# Altium schematic sheet 40-pin LCD Schematic.SchDoc("40-pin LCD Schematic")
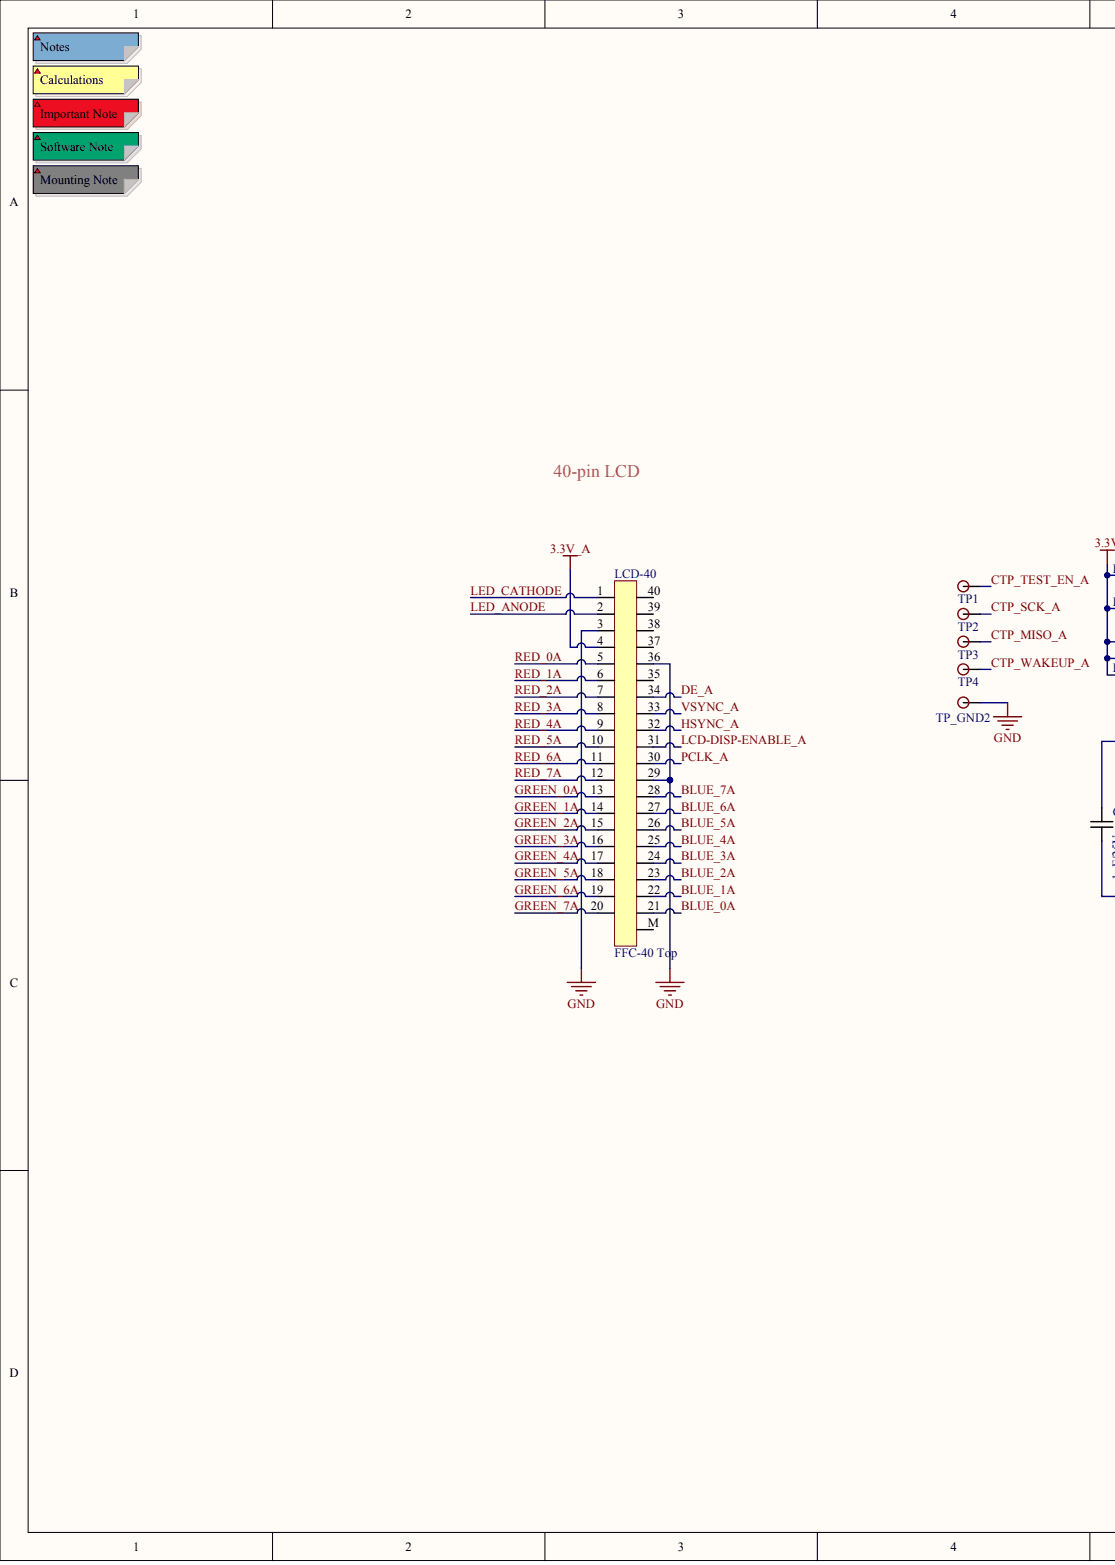
[diagram p0: 40-pin LCD Schematic.SchDoc, left]
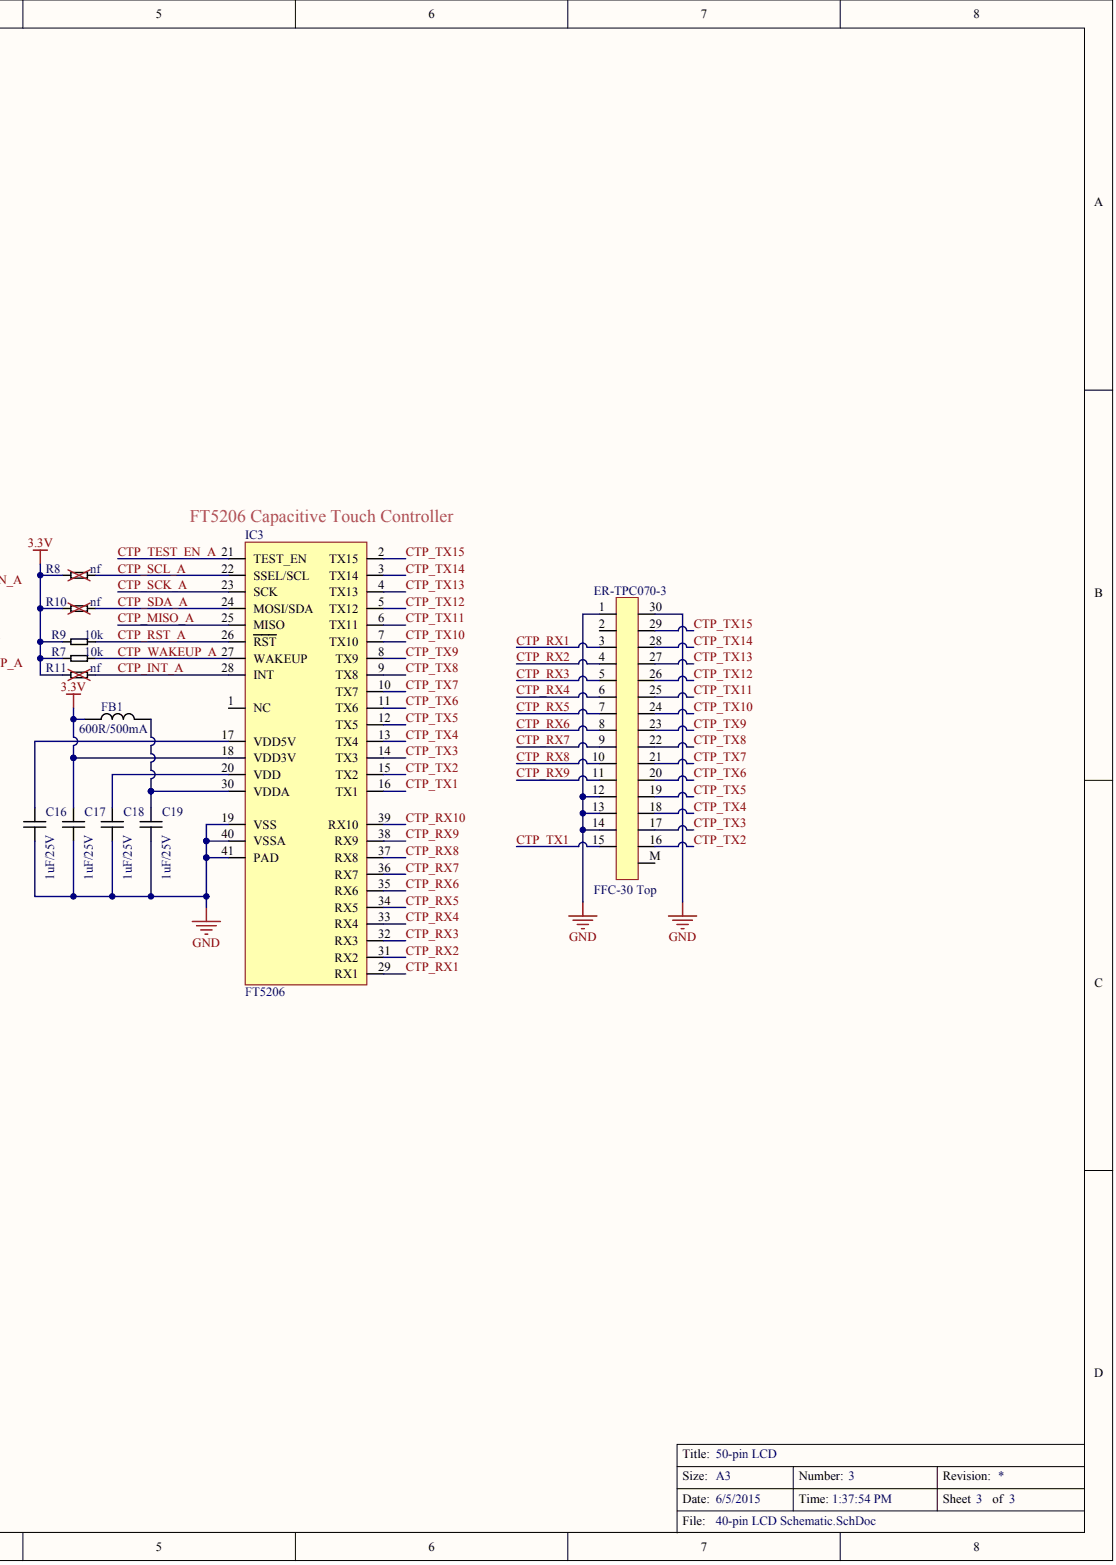
[diagram p1: 40-pin LCD Schematic.SchDoc, right]

## Parts
C16  value 1uF/25V  [0603]  (hidden comment: Cap_0603_1uF/25V)
C17  value 1uF/25V  [0603]  (hidden comment: Cap_0603_1uF/25V)
C18  value 1uF/25V  [0603]  (hidden comment: Cap_0603_1uF/25V)
C19  value 1uF/25V  [0603]  (hidden comment: Cap_0603_1uF/25V)
ER-TPC070-3  value FFC-30 Top  (hidden comment: FFC 30 pin 0.5mm Top)
FB1  value 600R/500mA  [0603]  (hidden comment: Ferrite_Bead_0603_600R_500mA)
IC3  FT5206  [QFN 40]
LCD-40  value FFC-40 Top  (hidden comment: FFC 40 pin 0.5mm Top)
R7  value 10k  [0603]  (hidden comment: Res_0603_10k)
R8  value nf  [0603]  (hidden comment: Res_0603_nf)
R9  value 10k  [0603]  (hidden comment: Res_0603_10k)
R10  value nf  [0603]  (hidden comment: Res_0603_nf)
R11  value nf  [0603]  (hidden comment: Res_0603_nf)
TP1  Testpad  (value not drawn)
TP2  Testpad  (value not drawn)
TP3  Testpad  (value not drawn)
TP4  Testpad  (value not drawn)
TP_GND2  Testpad  (value not drawn)

## Nets
3.3V: C17.2 FB1.1 IC3.18(VDD3V) R7.1 R8.1 R9.1 R10.1 R11.1
3.3V_A: LCD-40.4
GND: C16.1 C17.1 C18.1 C19.1 ER-TPC070-3.1 ER-TPC070-3.12 ER-TPC070-3.13 ER-TPC070-3.14 ER-TPC070-3.30 IC3.19(VSS) IC3.40(VSSA) IC3.41(PAD) LCD-40.3 LCD-40.29 LCD-40.36 TP_GND2.1
BLUE_0A: LCD-40.21
BLUE_1A: LCD-40.22
BLUE_2A: LCD-40.23
BLUE_3A: LCD-40.24
BLUE_4A: LCD-40.25
BLUE_5A: LCD-40.26
BLUE_6A: LCD-40.27
BLUE_7A: LCD-40.28
CTP_INT_A: IC3.28(INT) R11.2
CTP_MISO_A: IC3.25(MISO) TP3.1
CTP_RST_A: IC3.26(RST) R9.2
CTP_RX1: ER-TPC070-3.3 IC3.29(RX1)
CTP_RX2: ER-TPC070-3.4 IC3.31(RX2)
CTP_RX3: ER-TPC070-3.5 IC3.32(RX3)
CTP_RX4: ER-TPC070-3.6 IC3.33(RX4)
CTP_RX5: ER-TPC070-3.7 IC3.34(RX5)
CTP_RX6: ER-TPC070-3.8 IC3.35(RX6)
CTP_RX7: ER-TPC070-3.9 IC3.36(RX7)
CTP_RX8: ER-TPC070-3.10 IC3.37(RX8)
CTP_RX9: ER-TPC070-3.11 IC3.38(RX9)
CTP_RX10: IC3.39(RX10)
CTP_SCK_A: IC3.23(SCK) TP2.1
CTP_SCL_A: IC3.22(SSEL/SCL) R8.2
CTP_SDA_A: IC3.24(MOSI/SDA) R10.2
CTP_TEST_EN_A: IC3.21(TEST_EN) TP1.1
CTP_TX1: ER-TPC070-3.15 IC3.16(TX1)
CTP_TX2: ER-TPC070-3.16 IC3.15(TX2)
CTP_TX3: ER-TPC070-3.17 IC3.14(TX3)
CTP_TX4: ER-TPC070-3.18 IC3.13(TX4)
CTP_TX5: ER-TPC070-3.19 IC3.12(TX5)
CTP_TX6: ER-TPC070-3.20 IC3.11(TX6)
CTP_TX7: ER-TPC070-3.21 IC3.10(TX7)
CTP_TX8: ER-TPC070-3.22 IC3.9(TX8)
CTP_TX9: ER-TPC070-3.23 IC3.8(TX9)
CTP_TX10: ER-TPC070-3.24 IC3.7(TX10)
CTP_TX11: ER-TPC070-3.25 IC3.6(TX11)
CTP_TX12: ER-TPC070-3.26 IC3.5(TX12)
CTP_TX13: ER-TPC070-3.27 IC3.4(TX13)
CTP_TX14: ER-TPC070-3.28 IC3.3(TX14)
CTP_TX15: ER-TPC070-3.29 IC3.2(TX15)
CTP_WAKEUP_A: IC3.27(WAKEUP) R7.2 TP4.1
DE_A: LCD-40.34
GREEN_0A: LCD-40.13
GREEN_1A: LCD-40.14
GREEN_2A: LCD-40.15
GREEN_3A: LCD-40.16
GREEN_4A: LCD-40.17
GREEN_5A: LCD-40.18
GREEN_6A: LCD-40.19
GREEN_7A: LCD-40.20
HSYNC_A: LCD-40.32
LCD-DISP-ENABLE_A: LCD-40.31
LED_ANODE: LCD-40.2
LED_CATHODE: LCD-40.1
PCLK_A: LCD-40.30
RED_0A: LCD-40.5
RED_1A: LCD-40.6
RED_2A: LCD-40.7
RED_3A: LCD-40.8
RED_4A: LCD-40.9
RED_5A: LCD-40.10
RED_6A: LCD-40.11
RED_7A: LCD-40.12
VSYNC_A: LCD-40.33
NetC16_2: C16.2 IC3.17(VDD5V)
NetC18_2: C18.2 IC3.20(VDD)
NetC19_2: C19.2 FB1.2 IC3.30(VDDA)

Not connected: ER-TPC070-3 2 M; IC3 1(NC); LCD-40 35 37 38 39 40 M

## Library data of the parts
C16-C19 = (no symbol name): Part No.: C1608X5R1E105K080AC; Manufacturer: TDK; DigiKey: 445-5146-1-ND; Mouser: 810-C1608X5R1E105K; Farnell: 2346891; Price SEK (No VAT): 1
ER-TPC070-3 = (no symbol name): Part No.: 54104-3031; Manufacturer: Molex; RS: 670-2557 (10-pack); Mouser: 538-54104-3031; DigiKey: WM7117CT-ND; Farnell: *; Price SEK (No VAT): 19
FB1 = (no symbol name): Part No.: MMZ1608B601C; Manufacturer: TDK; RS: 786-5015; Price SEK (No VAT): 1; Mouser: 810-MMZ1608B601C; DigiKey: 445-2166-1-ND; Farnell: 1669687
IC3 = (no symbol name): Part No.: FT5206GE1; Manufacturer: FocalTech; DigiKey: *; Price SEK (No VAT): 25; Mouser: *; RS: *; Value: FT5206
LCD-40 = (no symbol name): Part No.: 54104-4031; Manufacturer: Molex; RS: 670-2645 (10-pack); Mouser: 538-54132-5062; DigiKey: WM6785CT-ND; Farnell: *; Price SEK (No VAT): 31
R7 R9 = (no symbol name): Part No.: CRCW060310K0FKEA; Manufacturer: Vishay; Price SEK (No VAT): 0,1; Mouser: 71-CRCW0603-10K-E3; DigiKey: 541-10.0KHCT-ND
